# Altium schematic sheet WPT_E.SchDoc
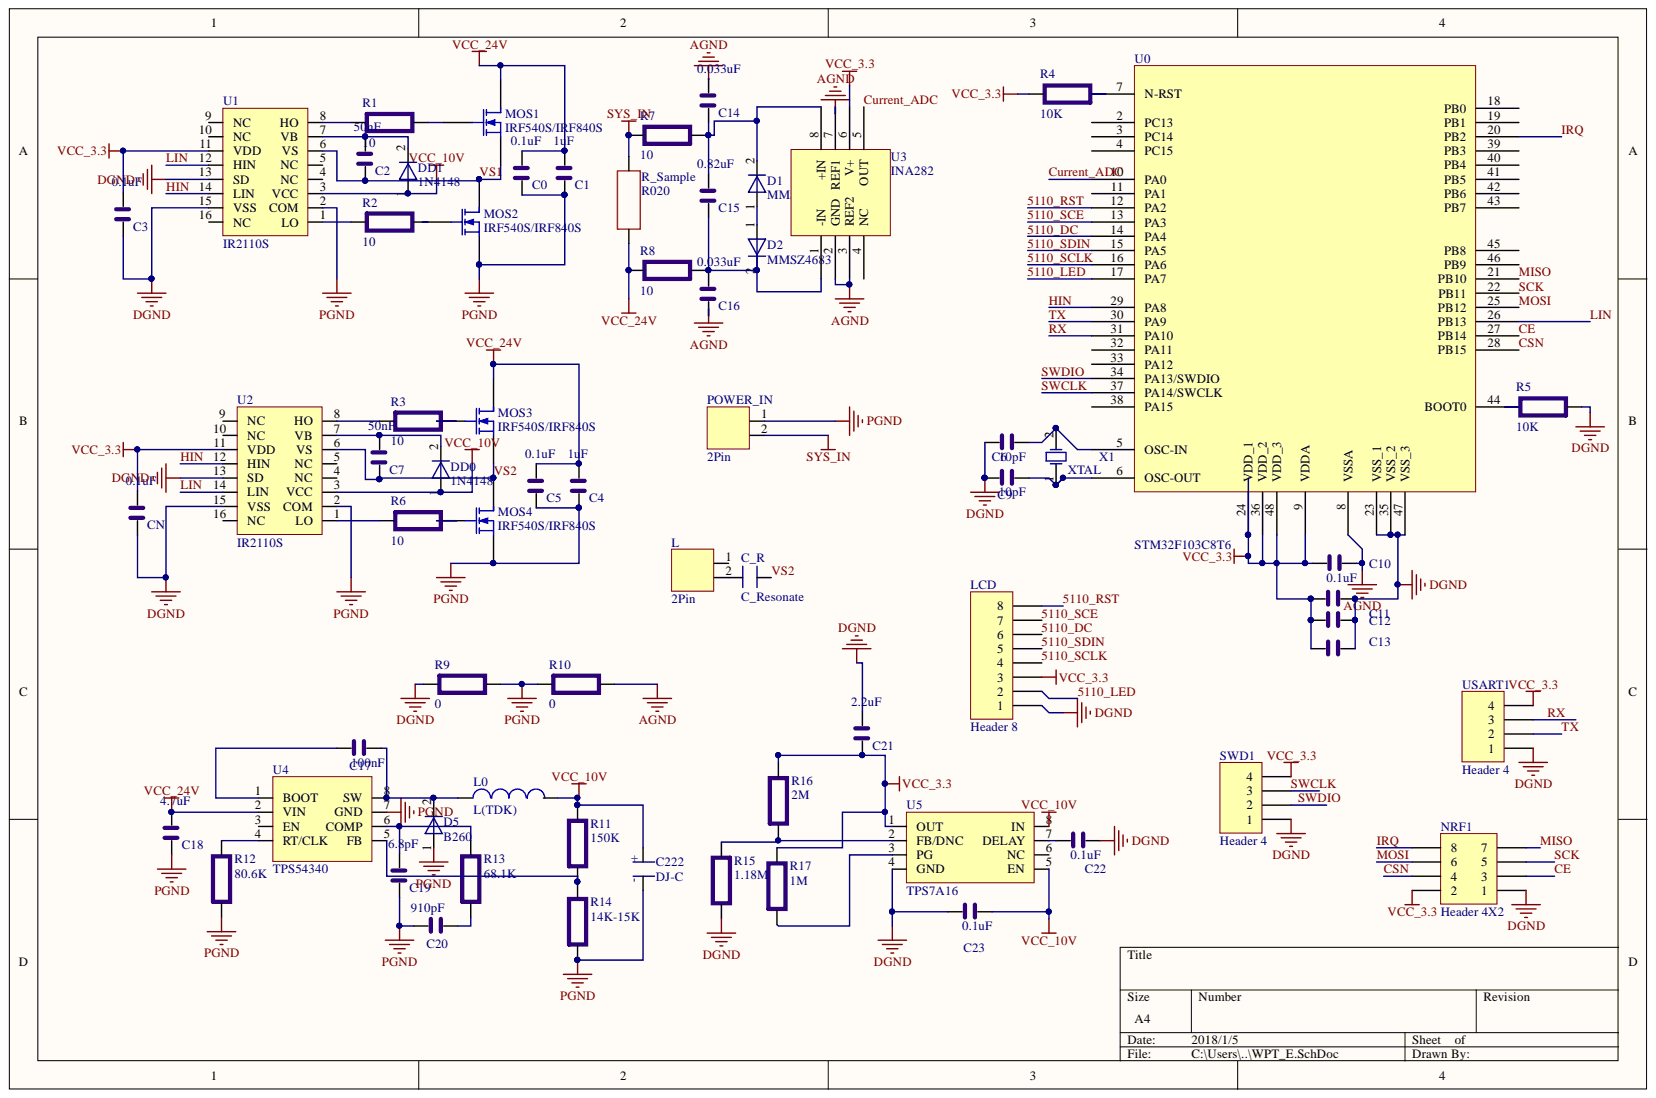
[diagram p0: WPT_E.SchDoc]

## Parts
C0  0.1uF  [0805]
C1  1uF  [0805]
C2  50nF  [0805]
C3  0.1uF  [0805]
C4  1uF  [0805]
C5  0.1uF  [0805]
C6  10pF  [0805]
C7  50nF  [0805]
C9  10pF  [0805]
C10  0.1uF  [0805]
C11  0.1uF  [0805]
C12  0.1uF  [0805]
C13  0.1uF  [0805]  (value not drawn)
C14  0.033uF  [0805]
C15  0.82uF  [0805]
C16  0.033uF  [0805]
C17  100nF  [0805]
C18  4.7uF  [0805]
C19  6.8pF  [0805]
C20  910pF  [0805]
C21  2.2uF  [0805]
C22  0.1uF  [0805]
C23  0.1uF  [0805]
C222  DJ-C  [C-dj]
C_R  C_Resonate  [C_Resonate]
CN  0.1uF  [0805]
D1  MMSZ4683  [MMSZ4683-D]
D2  MMSZ4683  [MMSZ4683-D]
D5  B260  [B260]
DD0  1N4148  [1N4148]
DD1  1N4148  [1N4148]
L  2Pin  [3Pin]
L0  L(TDK)  [L4_TPS54340]
LCD  Header 8  [HDR1X8]
MOS1  IRF540S/IRF840S  [IRF540]
MOS2  IRF540S/IRF840S  [IRF540]
MOS3  IRF540S/IRF840S  [IRF540]
MOS4  IRF540S/IRF840S  [IRF540]
NRF1  Header 4X2  [HDR2X4]
POWER_IN  2Pin  [3Pin]
R1  10  [0805]
R2  10  [0805]
R3  10  [0805]
R4  10K  [0805]
R5  10K  [0805]
R6  10  [0805]
R7  10  [0805]
R8  10  [0805]
R9  0  [0805]
R10  0  [0805]
R11  150K  [0805]
R12  80.6K  [0805]
R13  68.1K  [0805]
R14  14K-15K  [0805]
R15  1.18M  [0805]
R16  2M  [0805]
R17  1M  [0805]
R_Sample  R020  [2512]
SWD1  Header 4  [HDR1X4]
U0  STM32F103C8T6  [STM32C8T]
U1  IR2110S  [IR2110]
U2  IR2110S  [IR2110]
U3  INA282  [SOP8-INA282AIDR]
U4  TPS54340  [TPS54340]
U5  TPS7A16  [TPS7A16]
USART1  Header 4  [HDR1X4]
X1  XTAL  [XTAL]

## Nets
AGND: C10.1 C14.1 C16.2 R10.2 U0.8(VSSA) U3.2(GND) U3.3(REF2) U3.7(REF1)
DGND: C3.2 C6.2 C9.2 C11.1 C12.1 C13.1 C21.1 C22.1 C23.2 CN.2 LCD.1 NRF1.1 R5.1 R9.1 R15.2 SWD1.1 U0.23(VSS_1) U0.35(VSS_2) U0.47(VSS_3) U1.13(SD) U1.15(VSS) U2.13(SD) U2.15(VSS) U5.4(GND) USART1.1
PGND: C0.2 C1.2 C4.2 C5.2 C18.2 C19.2 C20.2 C222.2 D5.1 MOS2.3 MOS4.3 POWER_IN.1 R9.2 R10.1 R12.1 R14.1 U1.2(COM) U2.2(COM) U4.7(GND)
SYS_IN: POWER_IN.2 R7.2 R_Sample.1
VCC_3.3: C3.1 C10.2 C11.2 C12.2 C13.2 C21.2 CN.1 LCD.3 NRF1.2 R4.2 R16.2 R17.2 SWD1.4 U0.9(VDDA) U0.24(VDD_1) U0.36(VDD_2) U0.48(VDD_3) U1.11(VDD) U2.11(VDD) U3.6(V+) U5.1(OUT) USART1.4
VCC_10V: C23.1 C222.1 DD0.1 DD1.1 L0.2 R11.2 U1.3(VCC) U2.3(VCC) U5.5(EN) U5.8(IN)
VCC_24V: C0.1 C1.1 C4.1 C5.1 C18.1 MOS1.2 MOS3.2 R8.2 R_Sample.2 U4.2(VIN)
5110_DC: LCD.6 U0.14(PA4)
5110_LED: LCD.2 U0.17(PA7)
5110_RST: LCD.8 U0.12(PA2)
5110_SCE: LCD.7 U0.13(PA3)
5110_SCLK: LCD.4 U0.16(PA6)
5110_SDIN: LCD.5 U0.15(PA5)
CE: NRF1.3 U0.27(PB14)
CSN: NRF1.4 U0.28(PB15)
Current_ADC: U0.10(PA0) U3.5(OUT)
HIN: U0.29(PA8) U1.14(LIN) U2.12(HIN)
IRQ: NRF1.8 U0.20(PB2)
LIN: U0.26(PB13) U1.12(HIN) U2.14(LIN)
MISO: NRF1.7 U0.21(PB10)
MOSI: NRF1.6 U0.25(PB12)
RX: U0.31(PA10) USART1.3
SCK: NRF1.5 U0.22(PB11)
SWCLK: SWD1.3 U0.37(PA14/SWCLK)
SWDIO: SWD1.2 U0.34(PA13/SWDIO)
TX: U0.30(PA9) USART1.2
VS1: C2.2 MOS1.3 MOS2.2 U1.6(VS)
VS2: C7.2 C_R.2 MOS3.3 MOS4.2 U2.6(VS)
NetC2_1: C2.1 DD1.2 U1.7(VB)
NetC6_1: C6.1 U0.5(OSC-IN) X1.2
NetC7_1: C7.1 DD0.2 U2.7(VB)
NetC9_1: C9.1 U0.6(OSC-OUT) X1.1
NetC14_2: C14.2 C15.1 D1.2 R7.1 U3.8(+IN)
NetC15_2: C15.2 C16.1 D2.2 R8.1 U3.1(-IN)
NetC17_1: C17.1 D5.2 L0.1 U4.8(SW)
NetC17_2: C17.2 U4.1(BOOT)
NetC19_1: C19.1 R13.2 U4.6(COMP)
NetC20_1: C20.1 R13.1
NetC22_2: C22.2 U5.7(DELAY)
NetC_R_1: C_R.1 L.2
NetD1_1: D1.1 D2.1
NetMOS1_1: MOS1.1 R1.2
NetMOS2_1: MOS2.1 R2.2
NetMOS3_1: MOS3.1 R3.2
NetMOS4_1: MOS4.1 R6.2
NetR1_1: R1.1 U1.8(HO)
NetR2_1: R2.1 U1.1(LO)
NetR3_1: R3.1 U2.8(HO)
NetR4_1: R4.1 U0.7(N-RST)
NetR5_2: R5.2 U0.44(BOOT0)
NetR6_1: R6.1 U2.1(LO)
NetR11_1: R11.1 R14.2 U4.5(FB)
NetR12_2: R12.2 U4.4(RT/CLK)
NetR15_1: R15.1 R16.1 U5.2(FB/DNC)
NetR17_1: R17.1 U5.3(PG)

Not connected: L 1; U0 2(PC13) 3(PC14) 4(PC15) 11(PA1) 18(PB0) 19(PB1) 32(PA11) 33(PA12) 38(PA15) 39(PB3) 40(PB4) 41(PB5) 42(PB6) 43(PB7) 45(PB8) 46(PB9); U1 4(NC) 5(NC) 9(NC) 10(NC) 16(NC); U2 4(NC) 5(NC) 9(NC) 10(NC) 16(NC); U3 4(NC); U4 3(EN); U5 6(NC)

## Library data of the parts
C0-C7 C9-C23 CN = C0805: Component Kind: Standard; Library Name: WPT.IntLib
C222 = DJ-C: 电解电容 470uF 35V; Component Kind: Standard; Library Name: WPT.IntLib
DD0 DD1 = 1N4148: SOD523贴片0603; Component Kind: Standard; Library Name: WPT.IntLib
L POWER_IN = 2Pin: XT60; Component Kind: Standard; Library Name: WPT.IntLib
L0 = L(TDK): 10*10 10uH; Component Kind: Standard; Library Name: WPT.IntLib
LCD = Header 8: Header, 8-Pin; Component Kind: Standard; LatestRevisionDate: 17-Jul-2002; LatestRevisionNote: Re-released for DXP Platform.; Library Name: Miscellaneous Connectors.IntLib; Signal Integrity: Connector; Publisher: Altium Limited
MOS1-MOS4 = IRF540: Component Kind: Standard; Library Name: WPT.IntLib
NRF1 = Header 4X2: Header, 4-Pin, Dual row; Component Kind: Standard; LatestRevisionDate: 17-Jul-2002; LatestRevisionNote: Re-released for DXP Platform.; Library Name: Miscellaneous Connectors.IntLib; Signal Integrity: Connector; Publisher: Altium Limited
R1-R8 R10-R17 = R0805: Component Kind: Standard; Library Name: WPT.IntLib
R9 = R0805: 磁珠; Component Kind: Standard; Library Name: WPT.IntLib
R_Sample = R_Sample: Component Kind: Standard; Library Name: WPT.IntLib
SWD1 USART1 = Header 4: Header, 4-Pin; Component Kind: Standard; LatestRevisionDate: 17-Jul-2002; LatestRevisionNote: Re-released for DXP Platform.; Library Name: Miscellaneous Connectors.IntLib; Signal Integrity: Connector; Publisher: Altium Limited
U0 = STM32C8T6: Component Kind: Standard; Library Name: WPT.IntLib
U1 U2 = IR2110: Component Kind: Standard; Library Name: WPT.IntLib
X1 = XTAL: 直插式; Component Kind: Standard; Library Name: WPT.IntLib
C_R = C_Resonate: Component Kind: Standard; Library Name: WPT.IntLib
D1 D2 = MMSZ4683: Component Kind: Standard; Library Name: WPT.IntLib
D5 = B260: Component Kind: Standard; Library Name: WPT.IntLib
U3 = INA282: Component Kind: Standard; Library Name: WPT.IntLib
U4 = TPS54340: Component Kind: Standard; Library Name: WPT.IntLib
U5 = TPS7A16: Component Kind: Standard; Library Name: WPT.IntLib
